# Altium schematic sheet MCU.SchDoc(MCU)
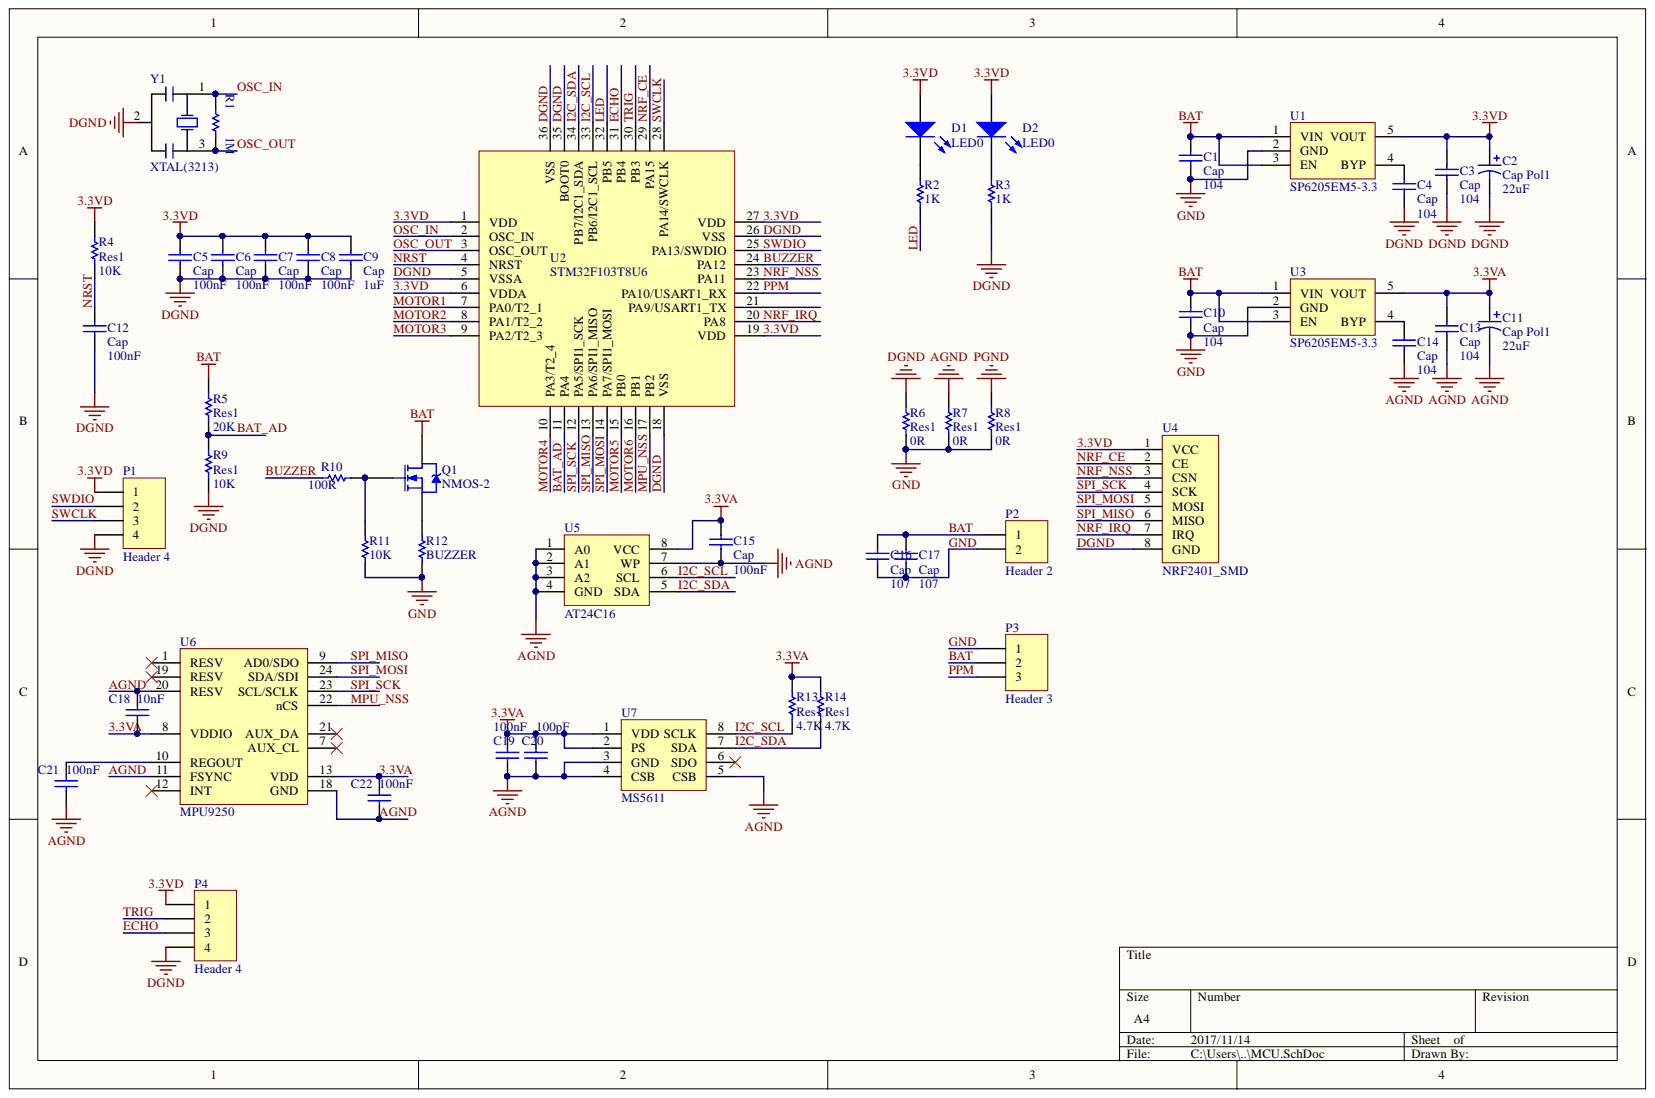
[diagram p0: MCU.SchDoc]

## Parts
C1  Cap  value 104  [C0402]
C2  Cap Pol1  value 22uF  [C0805]
C3  Cap  value 104  [C0402]
C4  Cap  value 104  [C0402]
C5  Cap  value 100nF  [C0402]
C6  Cap  value 100nF  [C0402]
C7  Cap  value 100nF  [C0402]
C8  Cap  value 100nF  [C0402]
C9  Cap  value 1uF  [C0402]
C10  Cap  value 104  [C0402]
C11  Cap Pol1  value 22uF  [C0805]
C12  Cap  value 100nF  [C0402]
C13  Cap  value 104  [C0402]
C14  Cap  value 104  [C0402]
C15  Cap  value 100nF  [C0402]
C16  Cap  value 107  [1206R]
C17  Cap  value 107  [1206R]
C18  value 10nF  [C0402]  (hidden comment: Cap)
C19  value 100nF  [C0402]  (hidden comment: Cap)
C20  value 100pF  [C0402]  (hidden comment: Cap)
C21  value 100nF  [C0402]  (hidden comment: Cap)
C22  value 100nF  [C0402]  (hidden comment: Cap)
D1  LED0  [0603D]
D2  LED0  [0603D]
P1  Header 4  [1.0*4PIN/SMD]
P2  Header 2  [BATPAD]
P3  Header 3  [PPMPAD]
P4  Header 4  [1.0*4PIN/SMD h]
Q1  NMOS-2  [SOT23]
R1  value 1M  [R0402]  (hidden comment: Res1)
R2  value 1K  [R0402]  (hidden comment: Res1)
R3  value 1K  [R0402]  (hidden comment: Res1)
R4  Res1  value 10K  [R0402]
R5  Res1  value 20K  [R0402]
R6  Res1  value 0R  [0805R]
R7  Res1  value 0R  [0805R]
R8  Res1  value 0R  [0805R]
R9  Res1  value 10K  [R0402]
R10  value 100R  [R0402]  (hidden comment: Res1)
R11  value 10K  [R0402]  (hidden comment: Res1)
R12  value BUZZER  [BUZZER5X5]  (hidden comment: Res1)
R13  Res1  value 4.7K  [R0402]
R14  Res1  value 4.7K  [R0402]
U1  SP6205EM5-3.3  [SOT-23-5]
U2  STM32F103T8U6  [VFQFPN36]
U3  SP6205EM5-3.3  [SOT-23-5]
U4  NRF2401_SMD  [NRF2401_SMD]
U5  AT24C16  [TSSOP8]
U6  MPU9250  [QFN24-3X3]
U7  MS5611  [MS5611]
Y1  XTAL(3213)  [Y3213]

## Nets
PGND: R8.2
3.3VA: C11.1 C13.2 C15.2 C18.1 C19.2 C20.2 C22.2 R13.2 R14.2 U3.5(VOUT) U5.8(VCC) U6.8(VDDIO) U6.13(VDD) U7.1(VDD) U7.2(PS)
3.3VD: C2.1 C3.2 C5.2 C6.2 C7.2 C8.2 C9.2 D1.1 D2.1 P1.1 P4.1 R4.2 U1.5(VOUT) U2.1(VDD) U2.6(VDDA) U2.19(VDD) U2.27(VDD) U4.1(VCC)
AGND: C11.2 C13.1 C14.1 C15.1 C18.2 C19.1 C20.1 C21.1 C22.1 R7.2 U5.1(A0) U5.2(A1) U5.3(A2) U5.4(GND) U5.7(WP) U6.11(FSYNC) U6.18(GND) U6.20(RESV) U7.3(GND) U7.4(CSB) U7.5(CSB)
BAT: C1.2 C10.2 C16.2 C17.2 P2.1 P3.2 Q1.3 R5.2 U1.1(VIN) U1.3(EN) U3.1(VIN) U3.3(EN)
BAT_AD: R5.1 R9.2 U2.11(PA4)
BUZZER: R10.1 U2.24(PA12)
DGND: C2.2 C3.1 C4.1 C5.1 C6.1 C7.1 C8.1 C9.1 C12.1 P1.4 P4.4 R3.2 R6.2 R9.1 U2.5(VSSA) U2.18(VSS) U2.26(VSS) U2.35(BOOT0) U2.36(VSS) U4.8(GND) Y1.2
ECHO: P4.3 U2.31(PB4)
GND: C1.1 C10.1 C16.1 C17.1 P2.2 P3.1 R6.1 R7.1 R8.1 R11.1 R12.2 U1.2(GND) U3.2(GND)
I2C_SCL: R13.1 U2.33(PB6/I2C1_SCL) U5.6(SCL) U7.8(SCLK)
I2C_SDA: R14.1 U2.34(PB7/I2C1_SDA) U5.5(SDA) U7.7(SDA)
LED: R2.2 U2.32(PB5)
MOTOR1: U2.7(PA0/T2_1)
MOTOR2: U2.8(PA1/T2_2)
MOTOR3: U2.9(PA2/T2_3)
MOTOR4: U2.10(PA3/T2_4)
MOTOR5: U2.15(PB0)
MOTOR6: U2.16(PB1)
MPU_NSS: U2.17(PB2) U6.22(nCS)
NRF_CE: U2.29(PA15) U4.2(CE)
NRF_IRQ: U2.20(PA8) U4.7(IRQ)
NRF_NSS: U2.23(PA11) U4.3(CSN)
NRST: C12.2 R4.1 U2.4(NRST)
OSC_IN: R1.2 U2.2(OSC_IN) Y1.1
OSC_OUT: R1.1 U2.3(OSC_OUT) Y1.3
PPM: P3.3 U2.22(PA10/USART1_RX)
SPI_MISO: U2.13(PA6/SPI1_MISO) U4.6(MISO) U6.9(AD0/SDO)
SPI_MOSI: U2.14(PA7/SPI1_MOSI) U4.5(MOSI) U6.24(SDA/SDI)
SPI_SCK: U2.12(PA5/SPI1_SCK) U4.4(SCK) U6.23(SCL/SCLK)
SWCLK: P1.3 U2.28(PA14/SWCLK)
SWDIO: P1.2 U2.25(PA13/SWDIO)
TRIG: P4.2 U2.30(PB3)
NetC4_2: C4.2 U1.4(BYP)
NetC14_2: C14.2 U3.4(BYP)
NetC21_2: C21.2 U6.10(REGOUT)
NetD1_2: D1.2 R2.1
NetD2_2: D2.2 R3.1
NetQ1_1: Q1.1 R10.2 R11.2
NetQ1_2: Q1.2 R12.1

Not connected: U2 21(PA9/USART1_TX); U6 1(RESV) 7(AUX_CL) 12(INT) 19(RESV) 21(AUX_DA); U7 6(SDO)

## Library data of the parts
C1 C3-C10 C12-C22 = Cap: Capacitor; Simulation: CAP; Signal Integrity: Cap; Published: 8-Jun-2000; PackageReference: RAD-0.3; Library Name: Miscellaneous Devices.IntLib; LatestRevisionNote: Re-released for DXP Platform.; LatestRevisionDate: 17-Jul-2002; Component Kind: Standard; Publisher: Altium Limited
C2 C11 = Cap Pol1: Polarized Capacitor (Radial); Simulation: CAP; Signal Integrity: Cap Pol; Published: 8-Jun-2000; PackageReference: RB7.6-15; Library Name: Miscellaneous Devices.IntLib; LatestRevisionNote: Re-released for DXP Platform.; LatestRevisionDate: 17-Jul-2002; Component Kind: Standard; Publisher: Altium Limited
D1 D2 = LED0: Typical INFRARED GaAs LED; Simulation: LED0; Published: 8-Jun-2000; PackageReference: LED-0; PackageDescription: LED; 2 Leads; Library Name: Miscellaneous Devices.IntLib; LatestRevisionNote: Mech Layer 1 removed.; LatestRevisionDate: 08-Jul-2005; Component Kind: Standard; Publisher: Altium Limited
P1 P4 = Header 4: Header, 4-Pin; Signal Integrity: Connector; Library Name: Miscellaneous Connectors.IntLib; LatestRevisionNote: Re-released for DXP Platform.; LatestRevisionDate: 17-Jul-2002; Component Kind: Standard; Publisher: Altium Limited
P2 = Header 2: Header, 2-Pin; Signal Integrity: Connector; Library Name: Miscellaneous Connectors.IntLib; LatestRevisionNote: Re-released for DXP Platform.; LatestRevisionDate: 17-Jul-2002; Component Kind: Standard; Publisher: Altium Limited
P3 = Header 3: Header, 3-Pin; Signal Integrity: Connector; Library Name: Miscellaneous Connectors.IntLib; LatestRevisionNote: Re-released for DXP Platform.; LatestRevisionDate: 17-Jul-2002; Component Kind: Standard; Publisher: Altium Limited
Q1 = NMOS-2: N-Channel Power MOSFET; Note: Pin 2 is electrically connected to pin 4.; Simulation: IRF1010; Published: 8-Jun-2000; PackageReference: TO-220AB; LatestRevisionNote: Re-released for DXP Platform.; LatestRevisionDate: 17-Jul-2002; DatasheetDocument: PD-9.814; ComponentLink1URL: http://www.irf.com/; ComponentLink1Description: Manufacturer Link; Component Kind: Standard; Code_JEDEC: TO-220-AB; Publisher: Altium Limited; Library Name: 四旋翼.SchLib
R1-R14 = Res1: Resistor; Simulation: RESISTOR; Signal Integrity: Res; Published: 8-Jun-2000; PackageReference: AXIAL-0.3; Library Name: Miscellaneous Devices.IntLib; LatestRevisionNote: Re-released for DXP Platform.; LatestRevisionDate: 17-Jul-2002; Component Kind: Standard; Publisher: Altium Limited
  R1-R9: PackageDescription: Axial Device, Thru-Hole; 2 Leads; 0.3 in Pin Spacing
U1 U3 = SP6205: AD3330; Component Kind: Standard; Library Name: 四旋翼.SchLib
Y1 = XTAL(3213): Crystal Oscillator; Published: 8-Jun-2000; PackageReference: R38; LatestRevisionNote: Re-released for DXP Platform.; LatestRevisionDate: 17-Jul-2002; Component Kind: Standard; Publisher: Altium Limited; Library Name: 四旋翼.SchLib
U2 = STM32F103T8U6: Component Kind: Standard; Library Name: 四旋翼.SchLib
U4 = NRF2401_SMD: Component Kind: Standard; Library Name: 四旋翼.SchLib
U5 = AT24C16: Component Kind: Standard; Library Name: 四旋翼.SchLib
U6 = MPU9250: Component Kind: Standard; Library Name: 四旋翼.SchLib
U7 = MS5611: Component Kind: Standard; Library Name: 四旋翼.SchLib
